# Altium schematic sheet PLL_Controller_STM32.SchDoc(PLL_Controller_STM32)
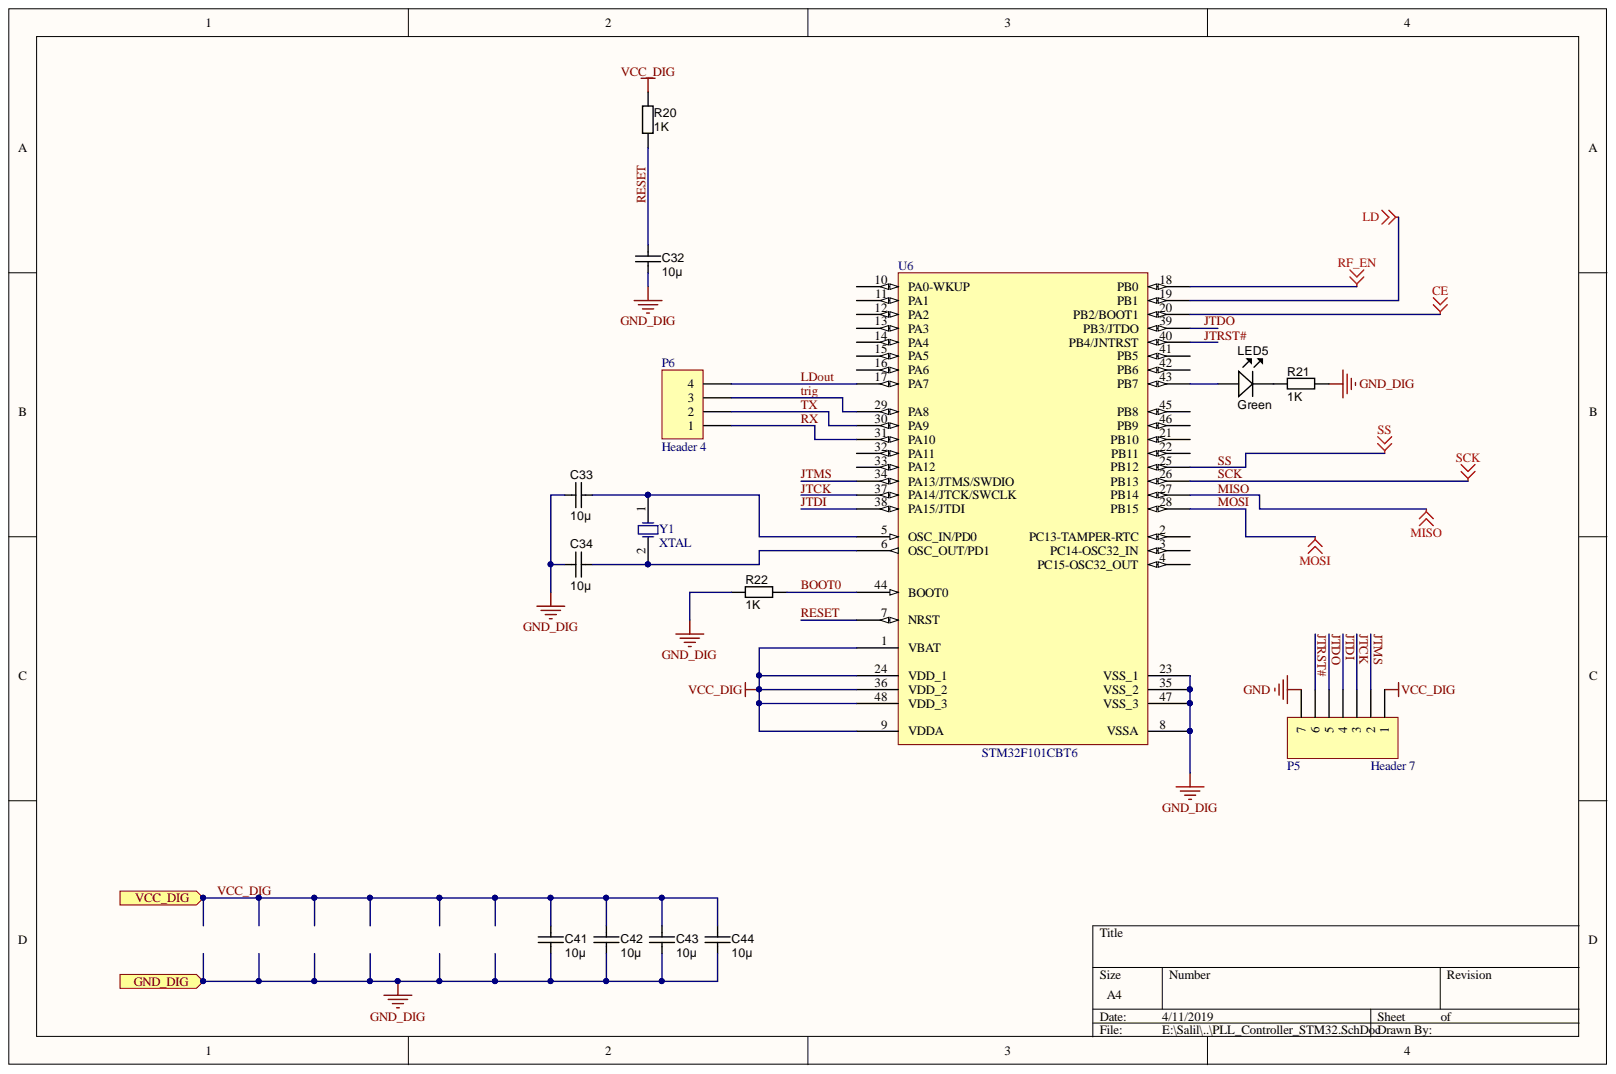
[diagram p0: PLL_Controller_STM32.SchDoc]

## Parts
C32  value 10µ  [CAPC2012X135N]  (hidden comment: approved sub OK)
C33  value 10µ  [CAPC2012X135N]  (hidden comment: approved sub OK)
C34  value 10µ  [CAPC2012X135N]  (hidden comment: approved sub OK)
C41  value 10µ  [CAPC2012X135N]  (hidden comment: approved sub OK)
C42  value 10µ  [CAPC2012X135N]  (hidden comment: approved sub OK)
C43  value 10µ  [CAPC2012X135N]  (hidden comment: approved sub OK)
C44  value 10µ  [CAPC2012X135N]  (hidden comment: approved sub OK)
LED5  Green  [LED-0603-GREEN]
P5  Header 7  [HDR1X7]
P6  Header 4  [HDR1X4]
R20  value 1K  [RESC1005X40N]  (hidden comment: Thick Film)
R21  value 1K  [RESC1005X40N]  (hidden comment: Thick Film)
R22  value 1K  [RESC1005X40N]  (hidden comment: Thick Film)
U6  STM32F101CBT6  [LQFP48_N]
Y1  XTAL  [R38]

## Ports
GND_DIG, VCC_DIG

## Nets
CE: U6.20(PB2/BOOT1)
GND: P5.7
LD: U6.19(PB1)
RF_EN: U6.18(PB0)
BOOT0: R22.2 U6.44(BOOT0)
GND_DIG: C32.1 C33.1 C34.1 C41.1 C42.1 C43.1 C44.1 R21.2 R22.1 U6.8(VSSA) U6.23(VSS_1) U6.35(VSS_2) U6.47(VSS_3) ; port GND_DIG
JTCK: P5.3 U6.37(PA14/JTCK/SWCLK)
JTDI: P5.4 U6.38(PA15/JTDI)
JTDO: P5.5 U6.39(PB3/JTDO)
JTMS: P5.2 U6.34(PA13/JTMS/SWDIO)
JTRST#: P5.6 U6.40(PB4/JNTRST)
LDout: P6.4 U6.17(PA7)
MISO: U6.27(PB14)
MOSI: U6.28(PB15)
RESET: C32.2 R20.1 U6.7(NRST)
RX: P6.1 U6.31(PA10)
SCK: U6.26(PB13)
SS: U6.25(PB12)
trig: P6.3 U6.29(PA8)
TX: P6.2 U6.30(PA9)
VCC_DIG: C41.2 C42.2 C43.2 C44.2 P5.1 R20.2 U6.1(VBAT) U6.9(VDDA) U6.24(VDD_1) U6.36(VDD_2) U6.48(VDD_3) ; port VCC_DIG
NetC33_2: C33.2 U6.5(OSC_IN/PD0) Y1.1
NetC34_2: C34.2 U6.6(OSC_OUT/PD1) Y1.2
NetLED5_A: LED5.A U6.43(PB7)
NetLED5_K: LED5.K R21.1

Not connected: U6 2(PC13-TAMPER-RTC) 3(PC14-OSC32_IN) 4(PC15-OSC32_OUT) 10(PA0-WKUP) 11(PA1) 12(PA2) 13(PA3) 14(PA4) 15(PA5) 16(PA6) 21(PB10) 22(PB11) 32(PA11) 33(PA12) 41(PB5) 42(PB6) 45(PB8) 46(PB9)

## Library data of the parts
C32-C34 C41-C44 = CAPC_10u_0805_10V: Capacitor SMD Cer 10uF 10% 10V X5R 0805; Manufacturer Part Number 1: 0805ZD106KAT2A; Manufacturer 1: AVX Corporation; SCDType: spec; Ratings: 10V; X5R; Material: ceramic; Tolerance: ±10%; Supplier Part Number 2: 399-4925-1-ND; Supplier Part Number 1: 478-5167-1-ND; Supplier 2: Digi-Key; Supplier 1: Digi-Key; Package / Case: 0805 (2012 metric); Manufacturer Part Number 2: C0805C106K8PACTU; Manufacturer 2: Kemet; Library Name: PBL - Capacitors.SCHLIB; ComponentLink2URL: http://www.kemet.com/datasheets&C0805C106K8PACTU; ComponentLink2Description: http://www.kemet.com/datasheets&C0805C106K8PACTU; ComponentLink1URL: http://www.avx.com/docs/Catalogs/cx5r.pdf; ComponentLink1Description: http://www.avx.com/docs/Catalogs/cx5r.pdf; Component Kind: Standard
LED5 = LED_SMD_Green_0603_570nm: LED 0603 SMD Green 570nm 50mcd 20mA; Manufacturer Part Number 1: APT1608CGCK; Manufacturer 1: Kingbright Company LLC; Wavelength - Peak: 574nm; Voltage - Forward (Vf) Typ: 2.1V; Voltage - Forward (Vf) @ 10mA: 2.0V; Viewing Angle: 120°; SCDType: source; Ratings: 20mcd min; 50 mcd typ; 570 nm; Material: AlGaInP; Lens Type: Clear; Lens Style/Size: Rectangle with Flat Top, 1.1mm x 0.8mm; Wavelength - Dominant: 570nm; Supplier Part Number 1: 754-1116-1-ND; Supplier 1: Digi-Key; RoHS 1: RoHS Compliant; Package / Case: 0603 (1608 metric); Library Name: PBL - Light Sources.SCHLIB; Current - Test: 20mA; ComponentLink1URL: http://www.us.kingbright.com/images/catalog/SPEC/APT1608CGCK.pdf; ComponentLink1Description: http://www.us.kingbright.com/images/catalog/SPEC/APT1608CGCK.pdf; Component Kind: Standard; Color: Green
P5 = Header 7: Header, 7-Pin; Signal Integrity: Connector; Library Name: Miscellaneous Connectors.IntLib; LatestRevisionNote: Re-released for DXP Platform.; LatestRevisionDate: 17-Jul-2002; Component Kind: Standard; Publisher: Altium Limited
P6 = Header 4: Header, 4-Pin; Signal Integrity: Connector; Library Name: Miscellaneous Connectors.IntLib; LatestRevisionNote: Re-released for DXP Platform.; LatestRevisionDate: 17-Jul-2002; Component Kind: Standard; Publisher: Altium Limited
R20-R22 = RES_1K_0402_5%: Resistor SMD chip 1k Ohm 0.063W 5% 0402; Manufacturer Part Number 1: RC0402JR-071KL; Manufacturer 1: Yageo; SCDType: spec; Ratings: 1/16 W; Tolerance: ±5%; Supplier Part Number 5: P1.0KJCT-ND; Supplier Part Number 4: RHM1.0KCECT-ND; Supplier Part Number 3: 1276-4376-1-ND; Supplier Part Number 2: 541-1.0KJCT-ND; Supplier Part Number 1: 311-1.0KJRCT-ND; Supplier 5: Digi-Key; Supplier 4: Digi-Key; Supplier 3: Digi-Key; Supplier 2: Digi-Key; Supplier 1: Digi-Key; RoHS 5: RoHS Compliant; RoHS 4: RoHS Compliant; RoHS 3: RoHS Compliant; RoHS 2: RoHS Compliant; RoHS 1: RoHS Compliant; Package / Case: 0402 (1005 Metric); Manufacturer Part Number 5: ERJ-2GEJ102X; Manufacturer Part Number 4: MCR01MRTJ102; Manufacturer Part Number 3: RC1005J102CS; Manufacturer Part Number 2: CRCW04021K00JNED; Manufacturer 5: Panasonic Electronic Components; Manufacturer 4: Rohm Semiconductor; Manufacturer 3: Samsung Electro-Mechanics America, Inc; Manufacturer 2: Vishay Dale; Library Name: PBL - Resistors.SCHLIB; ComponentLink5URL: http://industrial.panasonic.com/www-cgi/jvcr13pz.cgi?E+PZ+3+AOA0001+ERJ2GEJ102X+7+WW; ComponentLink5Description: http://industrial.panasonic.com/www-cgi/jvcr13pz.cgi?E+PZ+3+AOA0001+ERJ2GEJ102X+7+WW; ComponentLink4URL: http://rohmfs.rohm.com/en/products/databook/datasheet/passive/resistor/chip_resistor/mcr_g.pdf; ComponentLink4Description: http://rohmfs.rohm.com/en/products/databook/datasheet/passive/resistor/chip_resistor/mcr_g.pdf; ComponentLink3URL: http://www.samsungsem.com/servlet/FileDownload?filename=productcatalog/pdf/Chip_Resistor.pdf; ComponentLink3Description: http://www.samsungsem.com/servlet/FileDownload?filename=productcatalog/pdf/Chip_Resistor.pdf; ComponentLink2URL: http://www.vishay.com/doc?20035; ComponentLink2Description: http://www.vishay.com/doc?20035; ComponentLink1URL: http://www.yageo.com/documents/recent/PYu-RC0402_51_RoHS_L_6.pdf; ComponentLink1Description: http://www.yageo.com/documents/recent/PYu-RC0402_51_RoHS_L_6.pdf; Component Kind: Standard
U6 = STM32F101CBT6: STM32 ARM-based 32-bit MCU with 128 Kbytes Flash, 48-pin LQFP, Industrial Temperature; Published: 30-May-2008; PackageVersion: Mar-2008; PackageReference: LQFP48; PackageDescription: 48-Pin Low-Profile Quad Flat Pack, 7 x 7 mm Body, 0.5 mm Pitch (LQFP48); Library Name: ST Microcontroller 32-Bit STM32.IntLib; DatasheetVersion: rev6, Mar-2008; ComponentLink2URL: http://www.st.com/stonline/products/literature/ds/13586.pdf; ComponentLink2Description: Datasheet; ComponentLink1URL: www.st.com/stm32; ComponentLink1Description: Manufacturer Link; Component Kind: Standard; Publisher: Altium Limited
Y1 = XTAL: Crystal Oscillator; Simulation: XTAL; Published: 8-Jun-2000; PackageReference: R38; PackageDescription: Crystal, Thru-Hole; 2 Leads; Body 3.1 x 8.2 mm (Dia.xH); Library Name: Miscellaneous Devices.IntLib; LatestRevisionNote: Re-released for DXP Platform.; LatestRevisionDate: 17-Jul-2002; Component Kind: Standard; Publisher: Altium Limited
